# Altium schematic sheet GNET.SchDoc
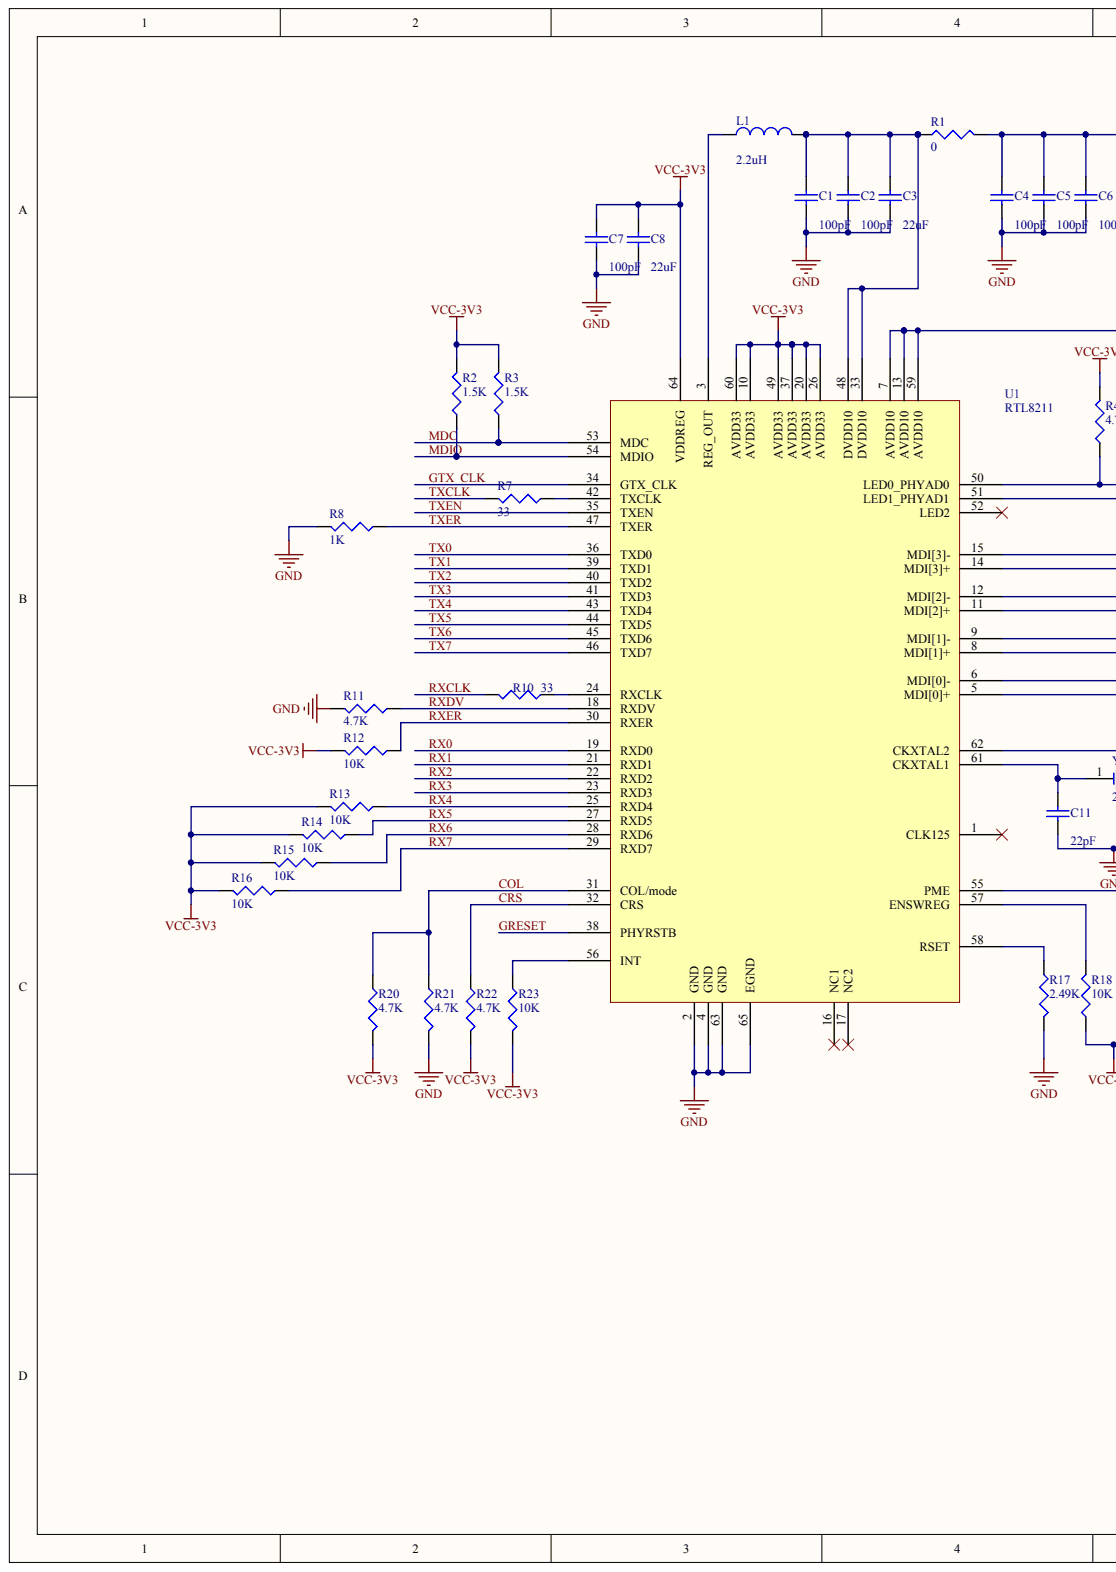
[diagram p0: GNET.SchDoc, left]
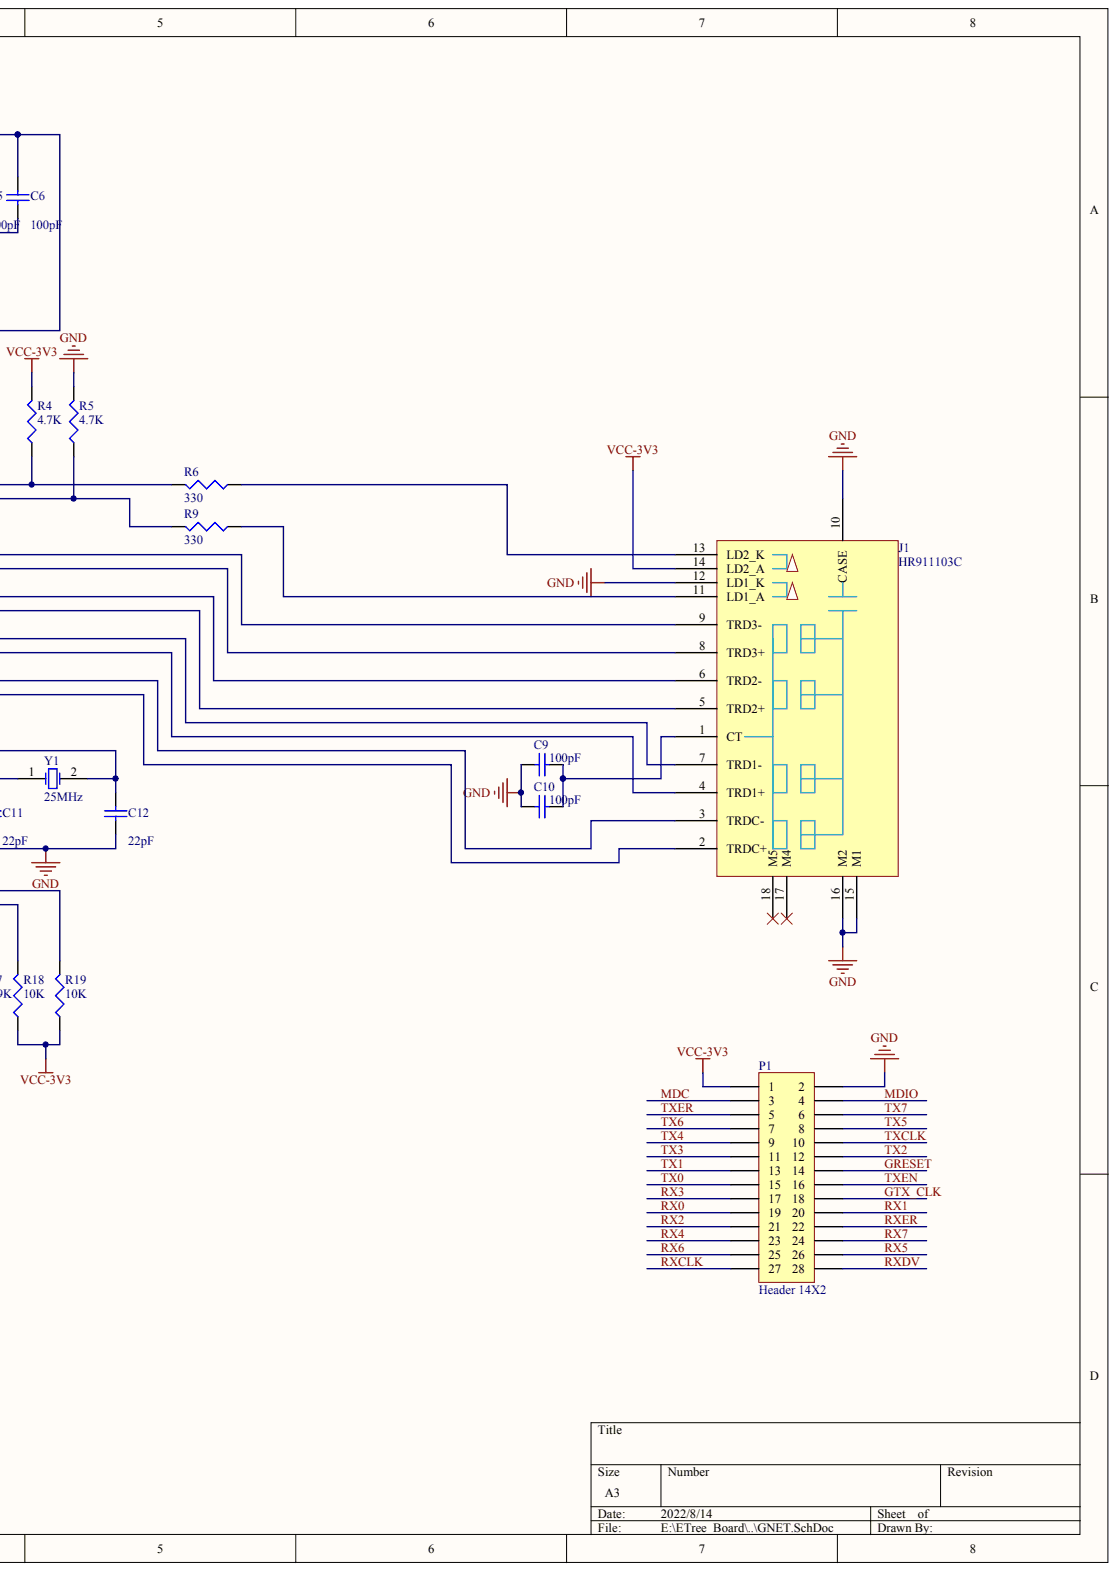
[diagram p1: GNET.SchDoc, right]

## Parts
C1  value 100pF  (hidden comment: Cap)
C2  value 100pF  (hidden comment: Cap)
C3  value 22uF  (hidden comment: Cap)
C4  value 100pF  (hidden comment: Cap)
C5  value 100pF  (hidden comment: Cap)
C6  value 100pF  (hidden comment: Cap)
C7  value 100pF  (hidden comment: Cap)
C8  value 22uF  (hidden comment: Cap)
C9  value 100pF  (hidden comment: Cap)
C10  value 100pF  (hidden comment: Cap)
C11  value 22pF  (hidden comment: Cap)
C12  value 22pF  (hidden comment: Cap)
J1  HR911103C
L1  value 2.2uH  (hidden comment: Inductor)
P1  Header 14X2
R1  value 0  (hidden comment: Res3)
R2  value 1.5K  (hidden comment: Res3)
R3  value 1.5K  (hidden comment: Res3)
R4  value 4.7K  (hidden comment: Res3)
R5  value 4.7K  (hidden comment: Res3)
R6  value 330  (hidden comment: Res3)
R7  value 33  (hidden comment: Res3)
R8  value 1K  (hidden comment: Res3)
R9  value 330  (hidden comment: Res3)
R10  value 33  (hidden comment: Res3)
R11  value 4.7K  (hidden comment: Res3)
R12  value 10K  (hidden comment: Res3)
R13  value 10K  (hidden comment: Res3)
R14  value 10K  (hidden comment: Res3)
R15  value 10K  (hidden comment: Res3)
R16  value 10K  (hidden comment: Res3)
R17  value 2.49K  (hidden comment: Res3)
R18  value 10K  (hidden comment: Res3)
R19  value 10K  (hidden comment: Res3)
R20  value 4.7K  (hidden comment: Res3)
R21  value 4.7K  (hidden comment: Res3)
R22  value 4.7K  (hidden comment: Res3)
R23  value 10K  (hidden comment: Res3)
U1  RTL8211
Y1  25MHz

## Nets
GND: C1.1 C2.1 C3.1 C4.1 C5.1 C6.1 C7.1 C8.1 C9.2 C10.2 C11.1 C12.1 J1.10(CASE) J1.12(LD1_K) J1.15(M1) J1.16(M2) P1.2 R5.2 R8.2 R11.2 R17.2 R21.2 U1.2(GND) U1.4(GND) U1.63(GND) U1.65(EGND)
VCC-3V3: C7.2 C8.2 J1.14(LD2_A) P1.1 R2.2 R3.2 R4.2 R12.2 R13.2 R14.2 R15.2 R16.2 R18.1 R19.1 R20.2 R22.2 R23.2 U1.10(AVDD33) U1.20(AVDD33) U1.26(AVDD33) U1.37(AVDD33) U1.49(AVDD33) U1.60(AVDD33) U1.64(VDDREG)
COL: R20.1 R21.1 U1.31(COL/mode)
CRS: R22.1 U1.32(CRS)
GRESET: P1.14 U1.38(PHYRSTB)
GTX_CLK: P1.18 U1.34(GTX_CLK)
MDC: P1.3 R3.1 U1.53(MDC)
MDIO: P1.4 R2.1 U1.54(MDIO)
RX0: P1.19 U1.19(RXD0)
RX1: P1.20 U1.21(RXD1)
RX2: P1.21 U1.22(RXD2)
RX3: P1.17 U1.23(RXD3)
RX4: P1.23 R13.1 U1.25(RXD4)
RX5: P1.26 R14.1 U1.27(RXD5)
RX6: P1.25 R15.1 U1.28(RXD6)
RX7: P1.24 R16.1 U1.29(RXD7)
RXCLK: P1.27 R10.2
RXDV: P1.28 R11.1 U1.18(RXDV)
RXER: P1.22 R12.1 U1.30(RXER)
TX0: P1.15 U1.36(TXD0)
TX1: P1.13 U1.39(TXD1)
TX2: P1.12 U1.40(TXD2)
TX3: P1.11 U1.41(TXD3)
TX4: P1.9 U1.43(TXD4)
TX5: P1.8 U1.44(TXD5)
TX6: P1.7 U1.45(TXD6)
TX7: P1.6 U1.46(TXD7)
TXCLK: P1.10 R7.2
TXEN: P1.16 U1.35(TXEN)
TXER: P1.5 R8.1 U1.47(TXER)
NetC1_2: C1.2 C2.2 C3.2 L1.2 R1.1 U1.33(DVDD10) U1.48(DVDD10)
NetC4_2: C4.2 C5.2 C6.2 R1.2 U1.7(AVDD10) U1.13(AVDD10) U1.59(AVDD10)
NetC9_1: C9.1 C10.1 J1.1(CT)
NetC11_2: C11.2 U1.61(CKXTAL1) Y1.1
NetC12_2: C12.2 U1.62(CKXTAL2) Y1.2
NetJ1_2: J1.2(TRDC+) U1.5(MDI[0]+)
NetJ1_3: J1.3(TRDC-) U1.6(MDI[0]-)
NetJ1_4: J1.4(TRD1+) U1.8(MDI[1]+)
NetJ1_5: J1.5(TRD2+) U1.11(MDI[2]+)
NetJ1_6: J1.6(TRD2-) U1.12(MDI[2]-)
NetJ1_7: J1.7(TRD1-) U1.9(MDI[1]-)
NetJ1_8: J1.8(TRD3+) U1.14(MDI[3]+)
NetJ1_9: J1.9(TRD3-) U1.15(MDI[3]-)
NetJ1_11: J1.11(LD1_A) R9.1
NetJ1_13: J1.13(LD2_K) R6.1
NetL1_1: L1.1 U1.3(REG_OUT)
NetR4_1: R4.1 R6.2 U1.50(LED0_PHYAD0)
NetR5_1: R5.1 R9.2 U1.51(LED1_PHYAD1)
NetR7_1: R7.1 U1.42(TXCLK)
NetR10_1: R10.1 U1.24(RXCLK)
NetR17_1: R17.1 U1.58(RSET)
NetR18_2: R18.2 U1.57(ENSWREG)
NetR19_2: R19.2 U1.55(PME)
NetR23_1: R23.1 U1.56(INT)

Not connected: J1 17(M4) 18(M5); U1 1(CLK125) 16(NC1) 17(NC2) 52(LED2)

## Library data of the parts
L1 = (no symbol name): Code_IEC: 1005; Published: 8-Jun-2000; LatestRevisionDate: 29-May-2009; LatestRevisionNote: IPC-7351 Footprint Added.; PackageReference: 0402-A; PackageDescription: Chip Inductor; Code_JEITA: 0402; Publisher: Altium Limited
C1-C12 J1 P1 R1-R23 U1 Y1 = (no symbol name)
  C1-C12: Published: 8-Jun-2000; LatestRevisionDate: 17-Jul-2002; LatestRevisionNote: Re-released for DXP Platform.; PackageReference: RAD-0.3; PackageDescription: Radial Cap, Thru-Hole; 2 Leads; 0.3 in Pin Spacing
  P1: LatestRevisionDate: 17-Jul-2002; LatestRevisionNote: Re-released for DXP Platform.
  R1-R23: Published: 8-Jun-2000; LatestRevisionDate: 29-May-2009; LatestRevisionNote: IPC-7351 Footprint Added.; PackageReference: J1-0603; PackageDescription: Chip Resistor
  Y1: Published: 8-Jun-2000; LatestRevisionDate: 17-Jul-2002; LatestRevisionNote: Re-released for DXP Platform.; PackageReference: R38; PackageDescription: Crystal, Thru-Hole; 2 Leads; Body 3.1 x 8.2 mm (Dia.xH)
  C1-C12 P1 R1-R23 Y1: Publisher: Altium Limited
